# Altium schematic sheet SAIDSense.SchDoc
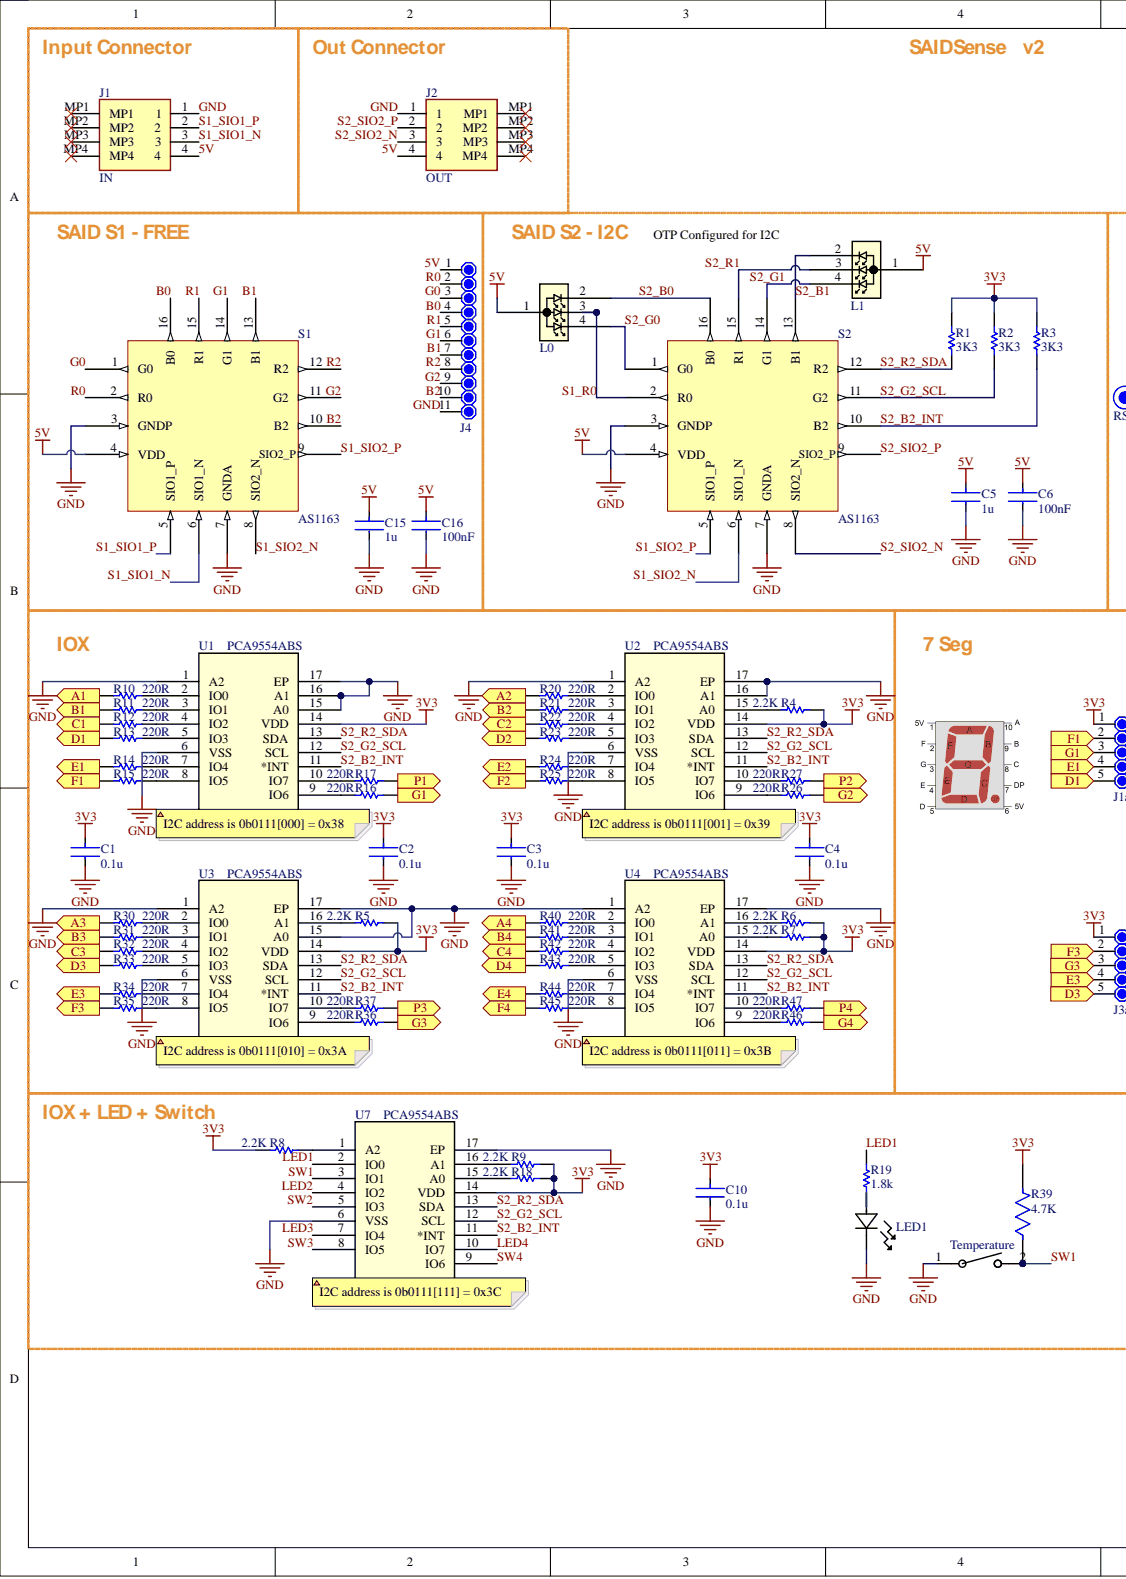
[diagram p0: SAIDSense.SchDoc, left]
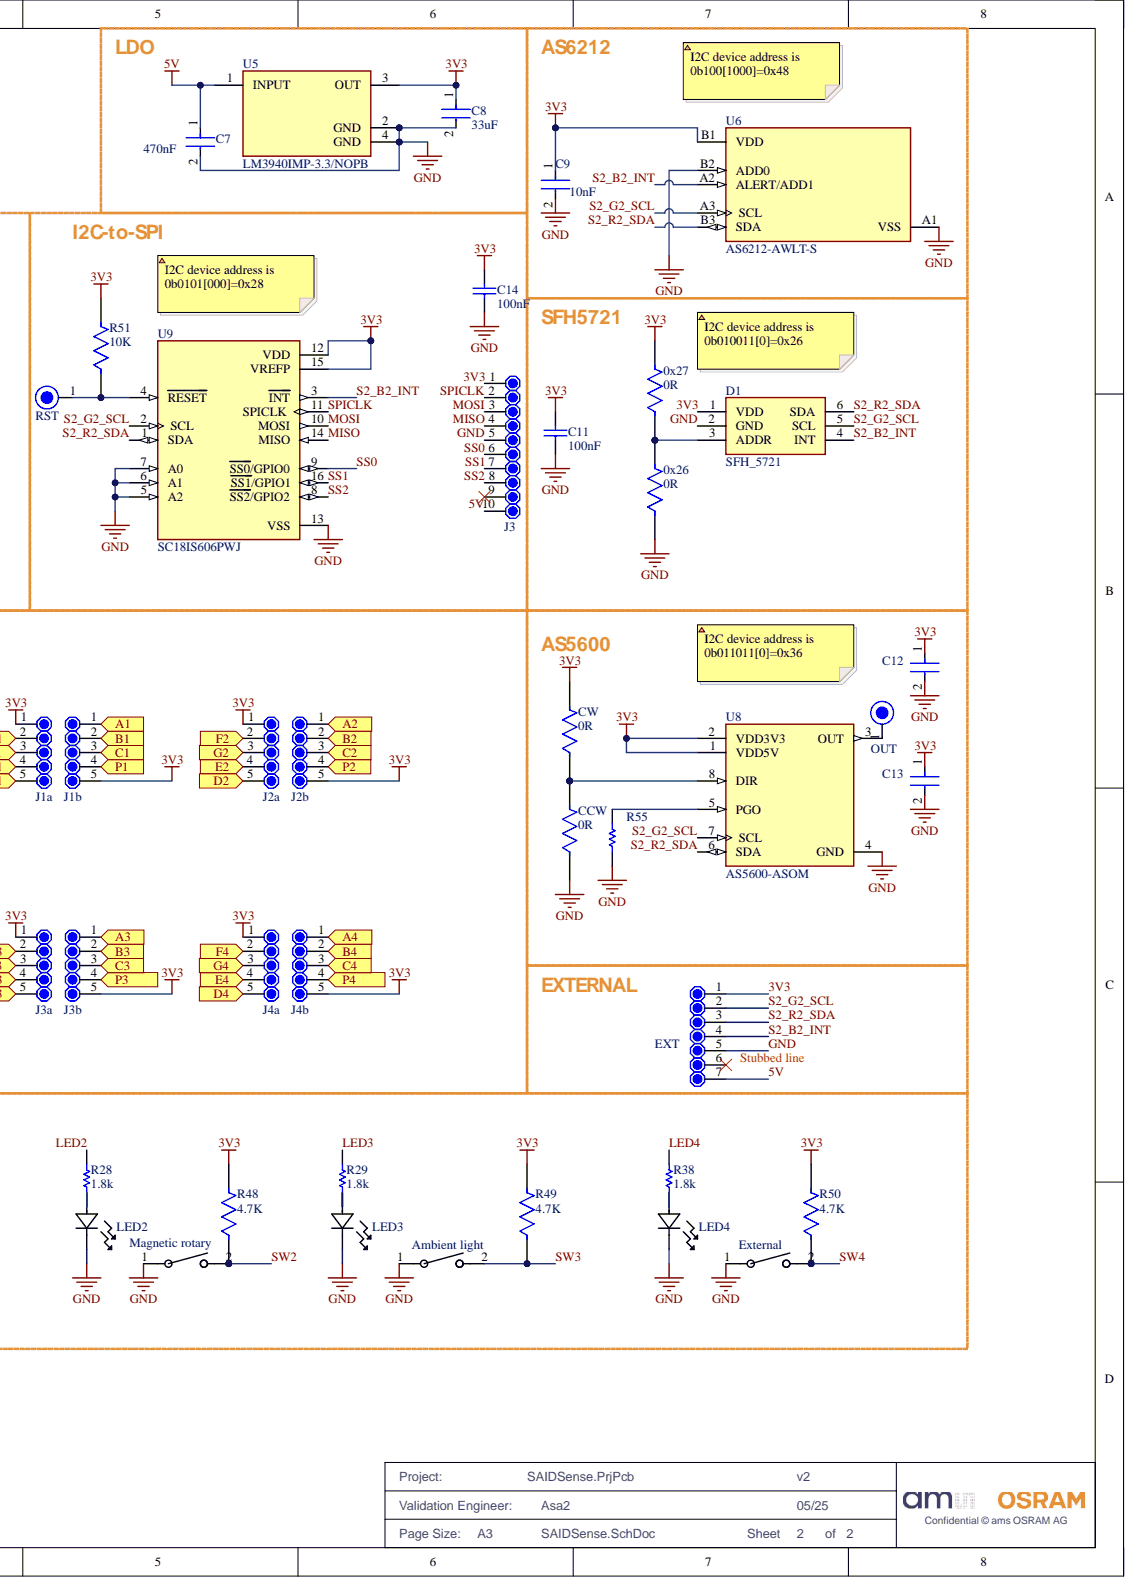
[diagram p1: SAIDSense.SchDoc, right]

## Parts
0x26  0R  [FP-CRG0603-IPC_C]
0x27  0R  [FP-CRG0603-IPC_C]  (no BOM)
Ambient_light  EVP-CD7C1A000  [SW_EVPCD7C1A000_PAN]  (value not drawn)
C1  0.1u  [FP-CGA3E-0_3-0_1-IPC_A]
C2  0.1u  [FP-CGA3E-0_3-0_1-IPC_A]
C3  0.1u  [FP-CGA3E-0_3-0_1-IPC_A]
C4  0.1u  [FP-CGA3E-0_3-0_1-IPC_A]
C5  1u  [FP-0603-L_1_6_0_15-W_0_81-MFG]
C6  100nF  [FP-CGA3E-0_3-0_1-IPC_A]
C7  470nF  [FP-CL10-IPC_A]
C8  33uF  [FP-C2012-125-0_2-IPC_A]
C9  10nF  [FP-C0603C-CF-MFG]
C10  0.1u  [FP-CGA3E-0_3-0_1-IPC_A]
C11  100nF  [CAPC1005X56X25LL10T15]
C12  CL05A106MP5NUNC  [FP-CL05A106MP5NUNC-MFG]  (value not drawn)
C13  CL05A106MP5NUNC  [FP-CL05A106MP5NUNC-MFG]  (value not drawn)
C14  100nF  [CAPC1005X56X25LL10T15]
C15  1u  [FP-0603-L_1_6_0_15-W_0_81-MFG]
C16  100nF  [FP-CGA3E-0_3-0_1-IPC_A]
CCW  0R  [FP-CRG0603-IPC_C]  (no BOM)
CW  0R  [FP-CRG0603-IPC_C]  (no BOM)
D1  SFH_5721  [SFH5721]
EXT  Pin Strip 7  [Pin_Strip_7]  (value not drawn)  (no BOM)
External  EVP-CD7C1A000  [SW_EVPCD7C1A000_PAN]  (value not drawn)
J1  IN  [214012E]
J1a  Pin Strip 5  [Pin_Strip_5]  (value not drawn)
J1b  Pin Strip 5  [Pin_Strip_5]  (value not drawn)
J2  OUT  [214012E]
J2a  Pin Strip 5  [Pin_Strip_5]  (value not drawn)
J2b  Pin Strip 5  [Pin_Strip_5]  (value not drawn)
J3  I2C-to-SPI  [Pin_Strip_10]
J3a  Pin Strip 5  [Pin_Strip_5]  (value not drawn)
J3b  Pin Strip 5  [Pin_Strip_5]  (value not drawn)
J4  Pin Strip 11  [Pin_Strip_11]  (value not drawn)
J4a  Pin Strip 5  [Pin_Strip_5]  (value not drawn)
J4b  Pin Strip 5  [Pin_Strip_5]  (value not drawn)
L0  (no value)  [KRTBDWLM32.32_AOS]
L1  (no value)  [KRTBDWLM32.32_AOS]
LED1  KW DELSS2.CC-BXCY-4O9Q-46A8  [LED_OSTUNE-E1608_AOS]  (value not drawn)
LED2  KW DELSS2.CC-BXCY-4O9Q-46A8  [LED_OSTUNE-E1608_AOS]  (value not drawn)
LED3  KW DELSS2.CC-BXCY-4O9Q-46A8  [LED_OSTUNE-E1608_AOS]  (value not drawn)
LED4  KW DELSS2.CC-BXCY-4O9Q-46A8  [LED_OSTUNE-E1608_AOS]  (value not drawn)
Magnetic_rotary  EVP-CD7C1A000  [SW_EVPCD7C1A000_PAN]  (value not drawn)
R1  3K3  [RESC0603(1608)_N]
R2  3K3  [RESC0603(1608)_N]
R3  3K3  [RESC0603(1608)_N]
R4  2.2K  [RESC1609X50X30NL10T20]
R5  2.2K  [RESC1609X50X30NL10T20]
R6  2.2K  [RESC1609X50X30NL10T20]
R7  2.2K  [RESC1609X50X30NL10T20]
R8  2.2K  [RESC1609X50X30NL10T20]
R9  2.2K  [RESC1609X50X30NL10T20]
R10  220R  [RESC1608X55X30NL20T10]
R11  220R  [RESC1608X55X30NL20T10]
R12  220R  [RESC1608X55X30NL20T10]
R13  220R  [RESC1608X55X30NL20T10]
R14  220R  [RESC1608X55X30NL20T10]
R15  220R  [RESC1608X55X30NL20T10]
R16  220R  [RESC1608X55X30NL20T10]
R17  220R  [RESC1608X55X30NL20T10]
R18  2.2K  [RESC1609X50X30NL10T20]
R19  1.8k  [RESC1608X55X30LL15T15]
R20  220R  [RESC1608X55X30NL20T10]
R21  220R  [RESC1608X55X30NL20T10]
R22  220R  [RESC1608X55X30NL20T10]
R23  220R  [RESC1608X55X30NL20T10]
R24  220R  [RESC1608X55X30NL20T10]
R25  220R  [RESC1608X55X30NL20T10]
R26  220R  [RESC1608X55X30NL20T10]
R27  220R  [RESC1608X55X30NL20T10]
R28  1.8k  [RESC1608X55X30LL15T15]
R29  1.8k  [RESC1608X55X30LL15T15]
R30  220R  [RESC1608X55X30NL20T10]
R31  220R  [RESC1608X55X30NL20T10]
R32  220R  [RESC1608X55X30NL20T10]
R33  220R  [RESC1608X55X30NL20T10]
R34  220R  [RESC1608X55X30NL20T10]
R35  220R  [RESC1608X55X30NL20T10]
R36  220R  [RESC1608X55X30NL20T10]
R37  220R  [RESC1608X55X30NL20T10]
R38  1.8k  [RESC1608X55X30LL15T15]
R39  4.7K  [FP-ERA3A-IPC_C]
R40  220R  [RESC1608X55X30NL20T10]
R41  220R  [RESC1608X55X30NL20T10]
R42  220R  [RESC1608X55X30NL20T10]
R43  220R  [RESC1608X55X30NL20T10]
R44  220R  [RESC1608X55X30NL20T10]
R45  220R  [RESC1608X55X30NL20T10]
R46  220R  [RESC1608X55X30NL20T10]
R47  220R  [RESC1608X55X30NL20T10]
R48  4.7K  [FP-ERA3A-IPC_C]
R49  4.7K  [FP-ERA3A-IPC_C]
R50  4.7K  [FP-ERA3A-IPC_C]
R51  10K  [FP-RC0402-0_4-IPC_C]
R55  CRCW06030000Z0EA  [RESC1609X50X30NL8T20]  (value not drawn)  (no BOM)
S1  AS1163  [QFN50P300X300X85_HS-17N]
S2  AS1163  [QFN50P300X300X85_HS-17N]
Temperature  EVP-CD7C1A000  [SW_EVPCD7C1A000_PAN]  (value not drawn)
TP1  OUT  [TESTPOINT]
TP2  RST  [TESTPOINT]
U1  PCA9554ABS  [SOT629-1_NXP]
U2  PCA9554ABS  [SOT629-1_NXP]
U3  PCA9554ABS  [SOT629-1_NXP]
U4  PCA9554ABS  [QFN50P300X300X100-17N-D]
U5  LM3940IMP-3.3/NOPB  [FP-DCY0004A-MFG]
U6  AS6212-AWLT-S  [FP-AS6212-AWLT-S-IPC_C]
U7  PCA9554ABS  [QFN50P300X300X100-17N-D]
U8  AS5600-ASOM  [FP-AS5600-ASOM-IPC_C]
U9  SC18IS606PWJ  [SOP65P640X110-16N]

## Ports
A1, A2, A3, A4, B1, B2, B3, B4, C1, C2, C3, C4, D1, D2, D3, D4, E1, E2, E3, E4, F1, F2, F3, F4, G1, G2, G3, G4, P1, P2, P3, P4

## Nets
3V3: 0x27.2 C1.1 C2.1 C3.1 C4.1 C8.1 C9.1 C10.1 C11.1 C12.1 C13.1 C14.1 CW.2 D1.1(VDD) EXT.1 J1a.1 J1b.5 J2a.1 J2b.5 J3.1 J3a.1 J3b.5 J4a.1 J4b.5 R1.2 R2.2 R3.2 R4.2 R5.2 R6.2 R7.2 R8.1 R9.2 R18.2 R39.2 R48.2 R49.2 R50.2 R51.2 U1.14(VDD) U2.14(VDD) U3.14(VDD) U4.14(VDD) U5.3(OUT) U6.B1(VDD) U7.14(VDD) U8.1(VDD5V) U8.2(VDD3V3) U9.12(VDD) U9.15(VREFP)
5V: C5.1 C6.1 C7.1 C15.1 C16.1 EXT.7 J1.4 J2.4 J3.10 J4.1 L0.1 L1.1 S1.4(VDD) S2.4(VDD) U5.1(INPUT)
A1: J1b.1 R10.1 ; port A1
A2: J2b.1 R20.1 ; port A2
A3: J3b.1 R30.1 ; port A3
A4: J4b.1 R40.1 ; port A4
B0: J4.4 S1.16(B0)
B1: J4.7 S1.13(B1)
B2: J4.10 S1.10(B2)
B3: J3b.2 R31.1 ; port B3
B4: J4b.2 R41.1 ; port B4
C1: J1b.3 R12.1 ; port C1
C2: J2b.3 R22.1 ; port C2
C3: J3b.3 R32.1 ; port C3
C4: J4b.3 R42.1 ; port C4
D1: J1a.5 R13.1 ; port D1
D2: J2a.5 R23.1 ; port D2
D3: J3a.5 R33.1 ; port D3
D4: J4a.5 R43.1 ; port D4
E1: J1a.4 R14.1 ; port E1
E2: J2a.4 R24.1 ; port E2
E3: J3a.4 R34.1 ; port E3
E4: J4a.4 R44.1 ; port E4
F1: J1a.2 R15.1 ; port F1
F2: J2a.2 R25.1 ; port F2
F3: J3a.2 R35.1 ; port F3
F4: J4a.2 R45.1 ; port F4
G0: J4.3 S1.1(G0)
G1: J4.6 S1.14(G1)
G2: J4.9 S1.11(G2)
G3: J3a.3 R36.2 ; port G3
G4: J4a.3 R46.2 ; port G4
GND: 0x26.1 Ambient_light.1 C1.2 C2.2 C3.2 C4.2 C5.2 C6.2 C7.2 C8.2 C9.2 C10.2 C11.2 C12.2 C13.2 C14.2 C15.2 C16.2 CCW.1 D1.2(GND) EXT.5 External.1 J1.1 J2.1 J3.5 J4.11 LED1.1 LED2.1 LED3.1 LED4.1 Magnetic_rotary.1 R55.1 S1.3(GNDP) S1.7(GNDA) S2.3(GNDP) S2.7(GNDA) Temperature.1 U1.1(A2) U1.6(VSS) U1.15(A0) U1.16(A1) U1.17(EP) U2.1(A2) U2.6(VSS) U2.16(A1) U2.17(EP) U3.1(A2) U3.6(VSS) U3.15(A0) U3.17(EP) U4.1(A2) U4.6(VSS) U4.17(EP) U5.2(GND) U5.4(GND) U6.A1(VSS) U6.B2(ADD0) U7.6(VSS) U7.17(EP) U8.4(GND) U9.5(A2) U9.6(A1) U9.7(A0) U9.13(VSS)
LED1: R19.1 U7.2(IO0)
LED2: R28.1 U7.4(IO2)
LED3: R29.2 U7.7(IO4)
LED4: R38.2 U7.10(IO7)
MISO: J3.4 U9.14(MISO)
MOSI: J3.3 U9.10(MOSI)
P1: J1b.4 R17.2 ; port P1
P2: J2b.4 R27.2 ; port P2
P3: J3b.4 R37.2 ; port P3
P4: J4b.4 R47.2 ; port P4
R0: J4.2 S1.2(R0)
R1: J4.5 S1.15(R1)
R2: J4.8 S1.12(R2)
S1_R0: L0.3 S2.2(R0)
S1_SIO1_N: J1.3 S1.6(SIO1_N)
S1_SIO1_P: J1.2 S1.5(SIO1_P)
S1_SIO2_N: S1.8(SIO2_N) S2.6(SIO1_N)
S1_SIO2_P: S1.9(SIO2_P) S2.5(SIO1_P)
S2_B0: L0.2 S2.16(B0)
S2_B1: L1.2 S2.13(B1)
S2_B2_INT: D1.4(INT) EXT.4 R3.1 S2.10(B2) U1.11(*INT) U2.11(*INT) U3.11(*INT) U4.11(*INT) U6.A2(ALERT/ADD1) U7.11(*INT) U9.3(INT)
S2_G0: L0.4 S2.1(G0)
S2_G1: L1.4 S2.14(G1)
S2_G2_SCL: D1.5(SCL) EXT.2 R2.1 S2.11(G2) U1.12(SCL) U2.12(SCL) U3.12(SCL) U4.12(SCL) U6.A3(SCL) U7.12(SCL) U8.7(SCL) U9.2(SCL)
S2_R1: L1.3 S2.15(R1)
S2_R2_SDA: D1.6(SDA) EXT.3 R1.1 S2.12(R2) U1.13(SDA) U2.13(SDA) U3.13(SDA) U4.13(SDA) U6.B3(SDA) U7.13(SDA) U8.6(SDA) U9.1(SDA)
S2_SIO2_N: J2.3 S2.8(SIO2_N)
S2_SIO2_P: J2.2 S2.9(SIO2_P)
SPICLK: J3.2 U9.11(SPICLK)
SS0: J3.6 U9.9(SS0/GPIO0)
SS1: J3.7 U9.16(SS1/GPIO1)
SS2: J3.8 U9.8(SS2/GPIO2)
SW1: R39.1 Temperature.2 U7.3(IO1)
SW2: Magnetic_rotary.2 R48.1 U7.5(IO3)
SW3: Ambient_light.2 R49.1 U7.8(IO5)
SW4: External.2 R50.1 U7.9(IO6)
Net0x26_2: 0x26.2 0x27.1 D1.3(ADDR)
NetCCW_2: CCW.2 CW.1 U8.8(DIR)
NetJ1a_3: J1a.3 R16.2 ; port G1
NetJ1b_2: J1b.2 R11.1 ; port B1
NetJ2a_3: J2a.3 R26.2 ; port G2
NetJ2b_2: J2b.2 R21.1 ; port B2
NetLED1_2: LED1.2 R19.2
NetLED2_2: LED2.2 R28.2
NetLED3_2: LED3.2 R29.1
NetLED4_2: LED4.2 R38.1
NetR4_1: R4.1 U2.15(A0)
NetR5_1: R5.1 U3.16(A1)
NetR6_1: R6.1 U4.16(A1)
NetR7_1: R7.1 U4.15(A0)
NetR8_2: R8.2 U7.1(A2)
NetR9_1: R9.1 U7.16(A1)
NetR10_2: R10.2 U1.2(IO0)
NetR11_2: R11.2 U1.3(IO1)
NetR12_2: R12.2 U1.4(IO2)
NetR13_2: R13.2 U1.5(IO3)
NetR14_2: R14.2 U1.7(IO4)
NetR15_2: R15.2 U1.8(IO5)
NetR16_1: R16.1 U1.9(IO6)
NetR17_1: R17.1 U1.10(IO7)
NetR18_1: R18.1 U7.15(A0)
NetR20_2: R20.2 U2.2(IO0)
NetR21_2: R21.2 U2.3(IO1)
NetR22_2: R22.2 U2.4(IO2)
NetR23_2: R23.2 U2.5(IO3)
NetR24_2: R24.2 U2.7(IO4)
NetR25_2: R25.2 U2.8(IO5)
NetR26_1: R26.1 U2.9(IO6)
NetR27_1: R27.1 U2.10(IO7)
NetR30_2: R30.2 U3.2(IO0)
NetR31_2: R31.2 U3.3(IO1)
NetR32_2: R32.2 U3.4(IO2)
NetR33_2: R33.2 U3.5(IO3)
NetR34_2: R34.2 U3.7(IO4)
NetR35_2: R35.2 U3.8(IO5)
NetR36_1: R36.1 U3.9(IO6)
NetR37_1: R37.1 U3.10(IO7)
NetR40_2: R40.2 U4.2(IO0)
NetR41_2: R41.2 U4.3(IO1)
NetR42_2: R42.2 U4.4(IO2)
NetR43_2: R43.2 U4.5(IO3)
NetR44_2: R44.2 U4.7(IO4)
NetR45_2: R45.2 U4.8(IO5)
NetR46_1: R46.1 U4.9(IO6)
NetR47_1: R47.1 U4.10(IO7)
NetR51_1: R51.1 TP2.1 U9.4(RESET)
NetR55_2: R55.2 U8.5(PGO)
NetTP1_1: TP1.1 U8.3(OUT)

Not connected: EXT 6; J1 MP1 MP2 MP3 MP4; J2 MP1 MP2 MP3 MP4; J3 9

## Library data of the parts
0x26 0x27 CCW CW = CMP-03211-011415-1: RES SMD 0 OHM JUMPER 1/10W 0603; Max Power Dissipation: 100mW; Number of Resistors: 1; Resistance: 0mR; Temperature Coefficient: 100ppm/°C; Tolerance: 0%; Voltage Rating: 50V; Case Code (Imperial): 0603; Case Code (Metric): 1608; Case/Package: 0603; Depth: 0.8mm; ELV: Compliant; Height: 0.45mm; Length: 1.6mm; Library Name: Altium Content Vault; Max Operating Temperature: 125°C; Min Operating Temperature: -55°C; Mount: Surface Mount; Number of Terminations: 2; Package Quantity: 5000; Packaging: Tape and Reel; Power Rating: 100mW; Radiation Hardening: No; Resistor Type: Thick Film; RoHS Compliant: Yes; Series: CRG, Neohm; Technology: THICK FILM; Termination: Solder; Weight: 7.1E-05oz; Width: 0.8mm
  0x26: Component Kind: Standard
Ambient_light External Magnetic_rotary Temperature = EVP-CD7C1A000: No Description Available; Mfr_Name: Panasonic; Component Kind: Standard; Library Name: EVP-CD7C1A000.SchLib
C1-C4 C6 C10 C16 = CMP-2000-07546-2: Cap Ceramic 100nF 50V X7R 10% Pad SMD 0603 +125°C Automotive T/R; Capacitance: 100nF; Case Code (Imperial): 0603; Case Code (Metric): 1608; Case/Package: 0603; Component Kind: Standard; Composition: Ceramic; Depth: 0.8mm; Dielectric: X7R; Height: 0.8mm; Lead Free: Lead Free; Length: 1.6mm; Library Name: Altium Content Vault; Max Operating Temperature: 125°C; Min Operating Temperature: -55°C; Mount: Surface Mount; Package Quantity: 1; Packaging: Tape and Reel; Radiation Hardening: No; Ratings: AEC-Q200; REACH SVHC: No SVHC; Termination: SMD/SMT; Thickness: 0.035inch; Tolerance: 10%; Voltage: 50V; Voltage Rating: 50V; Voltage Rating (DC): 50V; Weight: 7.1E-05oz; Width: 0.8mm
C5 C15 = CMP-1035-02592-4: General Purpose Ceramic Capacitor, 0603, 1u, 10%, X7R, 15%, 50V; Capacitance: 100nF; Dissipation Factor: 2.5%; Case Code (Imperial): 0603; Case Code (Metric): 1608; Case/Package: 0603; Component Kind: Standard; Contact Plating: Tin; Depth: 0.81mm; Dielectric: X7R; Dielectric Material: Ceramic; Height: 0.9mm; Lead Free: Lead Free; Length: 1.6mm; Library Name: Altium Content Vault; Max Operating Temperature: 125°C; Min Operating Temperature: -55°C; Mount: Surface Mount; Number of Pins: 2; Package Quantity: 1; Packaging: Tape and Reel; Radiation Hardening: No; REACH SVHC: No SVHC; Termination: SMD/SMT; Thickness: 0.035inch; Tolerance: 10%; Voltage: 50V; Voltage Rating: 50V; Voltage Rating (DC): 50V; Width: 0.81mm
C7 = CMP-13271-003124-1: Cap Ceramic 470nF 25V X7R ±10% Pad SMD 0603 +125°C T/R; Capacitance: 470nF; Case Code (Imperial): 0603; Case Code (Metric): 1608; Case/Package: 0603; Component Kind: Standard; Contact Plating: Tin; Dielectric: X7R; Length: 0.063inch; Library Name: Altium Content Vault; Max Operating Temperature: 125°C; Min Operating Temperature: -55°C; Mount: Surface Mount; Package Quantity: 1; Packaging: Cut Tape; Termination: Wraparound; Thickness: 0.035inch; Tolerance: 10%; Voltage Rating: 25V; Width: 0.031inch
C8 = CMP-08246-005951-1: Multilayer Ceramic Capacitors 33μF ±20% 4V X6S SMD 0805; Capacitance: 33uF; Case Code (Imperial): 0805; Case Code (Metric): 2012; Case/Package: 0805; Component Kind: Standard; Dielectric: X6S; Dielectric Material: Ceramic; Height: 1.25mm; Lead Free: Lead Free; Length: 0.079inch; Library Name: Altium Content Vault; Max Operating Temperature: 105°C; Min Operating Temperature: -55°C; Mount: Surface Mount; Package Quantity: 2000; Packaging: Tape and Reel; Resistor Type: Ceramic; RoHS Compliant: Yes; Series: C; Termination: SMD/SMT; Thickness: 0.057inch; Tolerance: 20%; Voltage Rating: 4V; Voltage Rating (DC): 4V; Width: 0.049inch
C9 = CMP-1035-02317-2: C0603 10,000 pF X7R 30ppm/°C 10.00% 25 V; Manufacturer: KEMET; Manufacturer Part Number: C0603C103K3RAC; Automotive Grade: Grade 1; Material: Ceramic; Tolerance: 0.1; Voltage Rating: 25 V; Automotive: Yes; Capacitance: 10,000 pF; Category: Cap; Component Kind: Standard; ComponentLink2Description: Datasheet; ComponentLink2URL: https://api.kemet.com/component-edge/download/datasheet/C0603C100J5GACAUTO.pdf; Device Class L1: Passive Components; Device Class L2: Capacitors; Device Class L3: Ceramic Capacitors; Height: 0.87mm; Lead Free: Yes; Library Name: Altium Content Vault; Mouser Description: Cap Ceramic 0.01uF 25V X7R 10% Pad SMD 0603 125C Bulk; Octopart: https://octopart.com/c0603c103k3rac-kemet-2224274; Package: C0603C-CF; RoHS: TRUE; Temperature: -55°C to +125°C; Temperature Characteristic: X7R; Temperature Coefficient: 30ppm/°C; Temperature Range High: +125°C; Temperature Range Low: -55°C; Value: 10,000 pF
C11 C14 = CMP-2008-02899-1: Manufacturer: AVX Interconnect / Elco; Part Number: 0402ZD104JAT2A; Component Kind: Standard; Library Name: Altium Content Vault
C12 C13 = CMP-13271-000002-1: CAP CER 10UF 10V X5R 0402; Manufacturer: Samsung; Material: Ceramic; Temperature Coefficient: 15%; Tolerance: 20%; Automotive: No; Capacitance: 10uF; Category: Cap; Component Kind: Standard; ComponentLink2Description: Datasheet; ComponentLink2URL: http://www.samsungsem.com/kr/support/product-search/mlcc/__icsFiles/afieldfile/2019/05/10/19-CL05A106MP5NUNC.pdf; Device Class L1: Passive Components; Device Class L2: Capacitors; Device Class L3: Ceramic Capacitors; Digikey Description: CAP CER 10UF 10V X5R 0402; Height: 0.7mm; Ipc Land Pattern Name: CAPC10050X50; Lead Free: Yes; Library Name: Altium Content Vault; Manufacturer Part Number: CL05A106MP5NUNC; Octopart: https://octopart.com/cl05a106mp5nunc-samsung-22457395; Package: CL05A106MP5NUNC; RoHS: TRUE; Temperature: -55°C to +85°C; Temperature Characteristic: X5R; Temperature Range High: +85°C; Temperature Range Low: -55°C; Value: 10uF; Voltage Rating: 10V
D1 = SFH_5721: Ambient Light Sensors ALS / PS Emitter ChipLED; Manufacturer_Name: ams OSRAM; Geometry.Height: 0.6mm; Component Kind: Standard; Datasheet Link: https://look.ams-osram.com/m/149e2b6ba561084/original/SFH-5721.pdf; Library Name: SFH_5721.SchLib; Manufacturer_Part_Number: SFH 5721; Mouser Part Number: 720-SFH5721A9489; Mouser Price/Stock: https://www.mouser.co.uk/ProductDetail/ams-OSRAM/SFH-5721?qs=TuK3vfAjtkVuP6sTM9hwPg%3D%3D
EXT = Pin_Strip_7: Manufacturer: Fischer Elektronik; Manufacturer Part Number: SL1.025.36Z; Current Rating: 3A; Gender: Male; Max Voltage Rating (AC): 250V; Number of Contacts: 36; Pitch: 2.54mm; Colour: Black; ComponentLink1Description: http://www.farnell.com/datasheets/12129.pdf; ComponentLink1URL: http://www.farnell.com/datasheets/12129.pdf; ComponentLink2Description: https://www.verical.com/server-webapp/html/getDatasheet?partID=640159; ComponentLink2URL: https://www.verical.com/server-webapp/html/getDatasheet?partID=640159; Contact Material: Bronze,Copper,Copper Alloy,Tin; Contact Plating: Tin; Depth: 2.5mm; Description: Pin Strip 7; Flammability Rating: UL94 V-0; Height: 2.5mm; Housing Material: Polyamide; Length: 91.44mm; Library Name: Mechanical_3D.SchLib; Max Current Rating: 3A; Max Operating Temperature: 163°C; Min Operating Temperature: -40°C; Number of Rows: 1; Orientation: Straight; REACH SVHC: No SVHC; Stock 1: 0; Supplier 1: Farnell; Supplier Part Number 1: 9729038; Termination: Solder; Width: 11.2mm
J1 J2 = 214012-E: Headers & Wire Housings 4-PIN,RIGHTANGLE,SMT; Manufacturer_Name: TE Connectivity; Geometry.Height: 2.98mm; Arrow Part Number: 214012-E; Arrow Price/Stock: https://www.arrow.com/en/products/214012-e/te-connectivity?region=europe; Component Kind: Standard; Datasheet Link: https://www.te.com/commerce/DocumentDelivery/DDEController?Action=showdoc&DocId=Customer+Drawing%7F214012-E%7FK%7Fpdf%7FEnglish%7FENG_CD_214012-E_K.pdf%7F214012-E; Library Name: 214012-E.SchLib; Manufacturer_Part_Number: 214012-E
J1a J1b J2a J2b J3a J3b J4a J4b = Pin_Strip_5: Manufacturer: Fischer Elektronik; Manufacturer Part Number: SL1.025.36Z; Current Rating: 3A; Gender: Male; Max Voltage Rating (AC): 250V; Number of Contacts: 36; Pitch: 2.54mm; Colour: Black; Component Kind: Standard; ComponentLink1Description: http://www.farnell.com/datasheets/12129.pdf; ComponentLink1URL: http://www.farnell.com/datasheets/12129.pdf; ComponentLink2Description: https://www.verical.com/server-webapp/html/getDatasheet?partID=640159; ComponentLink2URL: https://www.verical.com/server-webapp/html/getDatasheet?partID=640159; Contact Material: Bronze,Copper,Copper Alloy,Tin; Contact Plating: Tin; Depth: 2.5mm; Description: Pin Strip 5; Flammability Rating: UL94 V-0; Height: 2.5mm; Housing Material: Polyamide; Length: 91.44mm; Library Name: Mechanical_3D.SchLib; Max Current Rating: 3A; Max Operating Temperature: 163°C; Min Operating Temperature: -40°C; Number of Rows: 1; Orientation: Straight; REACH SVHC: No SVHC; Stock 1: 0; Supplier 1: Farnell; Supplier Part Number 1: 9729038; Termination: Solder; Width: 11.2mm
J3 = Pin_Strip_10: Pin Strip 10; Manufacturer: Fischer Elektronik; Manufacturer Part Number: SL1.025.36Z; Current Rating: 3A; Gender: Male; Max Voltage Rating (AC): 250V; Number of Contacts: 36; Pitch: 2.54mm; Colour: Black; Component Kind: Standard; ComponentLink1Description: http://www.farnell.com/datasheets/12129.pdf; ComponentLink1URL: http://www.farnell.com/datasheets/12129.pdf; ComponentLink2Description: https://www.verical.com/server-webapp/html/getDatasheet?partID=640159; ComponentLink2URL: https://www.verical.com/server-webapp/html/getDatasheet?partID=640159; Contact Material: Bronze,Copper,Copper Alloy,Tin; Contact Plating: Tin; Depth: 2.5mm; Flammability Rating: UL94 V-0; Height: 2.5mm; Housing Material: Polyamide; Length: 91.44mm; Library Name: Mechanical_3D.SchLib; Max Current Rating: 3A; Max Operating Temperature: 163°C; Min Operating Temperature: -40°C; Number of Rows: 1; Orientation: Straight; REACH SVHC: No SVHC; Stock 1: 0; Supplier 1: Farnell; Supplier Part Number 1: 9729038; Termination: Solder; Width: 11.2mm
J4 = Pin_Strip_11: Manufacturer: Fischer Elektronik; Manufacturer Part Number: SL1.025.36Z; Current Rating: 3A; Gender: Male; Max Voltage Rating (AC): 250V; Number of Contacts: 36; Pitch: 2.54mm; Colour: Black; Component Kind: Standard; ComponentLink1Description: http://www.farnell.com/datasheets/12129.pdf; ComponentLink1URL: http://www.farnell.com/datasheets/12129.pdf; ComponentLink2Description: https://www.verical.com/server-webapp/html/getDatasheet?partID=640159; ComponentLink2URL: https://www.verical.com/server-webapp/html/getDatasheet?partID=640159; Contact Material: Bronze,Copper,Copper Alloy,Tin; Contact Plating: Tin; Depth: 2.5mm; Description: Pin Strip 11; Flammability Rating: UL94 V-0; Height: 2.5mm; Housing Material: Polyamide; Length: 91.44mm; Library Name: Mechanical_3D.SchLib; Max Current Rating: 3A; Max Operating Temperature: 163°C; Min Operating Temperature: -40°C; Number of Rows: 1; Orientation: Straight; REACH SVHC: No SVHC; Stock 1: 0; Supplier 1: Farnell; Supplier Part Number 1: 9729038; Termination: Solder; Width: 11.2mm
L0 L1 = KRTBDWLM32.32-T4U6-JW+AAAB-J3R4S6-5V-S: No Description Available; Mfr_Name: ams OSRAM; Type: LED Indication; Component Kind: Standard; Library Name: KRTBDWLM32P32-T4U6-JW&plus_AAAB-J3R4S6-5V-S.SchLib; Manufacturer_Part_Number: KRTBDWLM32.32-T4U6-JW+AAAB-J3R4S6-5V-S; RefDes: RefDes; Copyright: Copyright (C) 2023 Ultra Librarian. All rights reserved.
LED1-LED4 = KW DELSS2.CC-BXCY-4O9Q-46A8: No Description Available; Mfr_Name: ams OSRAM; Type: DEV; Component Kind: Standard; Library Name: KWDELSS2PCC-BXCY-4O9Q-46A8.SchLib; Manufacturer_Part_Number: KW DELSS2.CC-BXCY-4O9Q-46A8; RefDes: RefDes; Copyright: Copyright (C) 2023 Ultra Librarian. All rights reserved.
R1-R3 = CMP-1012-00086-1: 3K3 0.1W 5% 0603 (1608 Metric)  SMD; Case-EIA: 0603; Case-Metric: 1608; Value: 3300.00 Ohm; Component Kind: Standard; Library Name: Altium Content Vault; Power: 0.1 W; Technology: SMT; Tolerance: 5 %
R4-R9 R18 = CMP-2000-03168-1: Manufacturer: Vishay Dale; Part Number: CRCW06032K20FKEB; Component Kind: Standard; Library Name: Altium Content Vault
R10-R17 R20-R27 R30-R37 R40-R47 = CMP-2000-01304-1: Manufacturer: KOA Speer; Part Number: RK73H1JTTD2200F; Component Kind: Standard; Library Name: Altium Content Vault
R19 R28 R29 R38 = CMP-2000-00336-1: Manufacturer: Panasonic; Part Number: ERJ3EKF2201V; Component Kind: Standard; Library Name: Altium Content Vault
R39 R48-R50 = CMP-2000-00874-2: RES SMD 4.7K OHM 0.1% 1/10W 0603; Max Power Dissipation: 100mW; Resistance: 10kR; Temperature Coefficient: 25ppm/°C; Voltage Rating: 75V; Case Code (Imperial): 0603; Case Code (Metric): 1608; Case/Package: 0603; Component Kind: Standard; Construction: Rectangular; Contact Plating: Tin; Depth: 0.8mm; Height: 0.45mm; Lead Free: Lead Free; Length: 1.6mm; Library Name: Altium Content Vault; Max Operating Temperature: 155°C; Military Standard: Not; Min Operating Temperature: -55°C; Mount: Surface Mount; Number of Pins: 2; Number of Terminations: 2; Package Quantity: 5000; Packaging: Tape and Reel; Power Rating: 100mW; Radiation Hardening: No; REACH SVHC: No SVHC; Resistance Tolerance: 0.1%; Resistor Type: Thin Film; RoHS Compliant: Yes; Series: ERA-3A; Size Code: 0603; Technology: METAL FILM; Termination: SMD/SMT; Tolerance: 0.1%; Voltage Rating (DC): 75V; Width: 0.8mm
R51 = CMP-2002-07915-3: RES 10K OHM 5% 1/16W 0402; Max Power Dissipation: 63mW; Power Rating: 62.5mW; Resistance: 10kR; Temperature Coefficient: 100ppm/°C; Voltage Rating: 50V; Case Code (Imperial): 0402; Case Code (Metric): 1005; Case/Package: 0402; Component Kind: Standard; Construction: Rectangular; Depth: 0.5mm; Features: Moisture Resistant; Height: 0.016inch; Lead Free: Lead Free; Length: 0.039inch; Library Name: Altium Content Vault; Max Operating Temperature: 155°C; Military Standard: Not; Min Operating Temperature: -55°C; Number of Terminations: 2; Package Quantity: 1; Packaging: Tape and Reel; Resistance Tolerance: 5%; Resistor Type: Thick Film; RoHS Compliant: Yes; Series: RC; Size Code: 0402; Termination: SMD/SMT; Tolerance: 5%; Width: 0.02inch
R55 = CMP-2000-03816-5: Chip Resistor, 0 Ohm, Jumper, 100 mW, -55 to 155 degC, 0603 (1608 Metric), RoHS, Tape and Reel; Manufacturer: Vishay Semiconductor; Voltage Rating: 75 V; ComponentLink1Description: Manufacturer URL; ComponentLink1URL: http://www.vishay.com/; ComponentLink2Description: Datasheet; ComponentLink2URL: http://www.vishay.com/docs/20035/dcrcwe3.pdf; DatasheetVersion: 06/2012; Height: 0.45 mm; Length: 1.55 mm; Library Name: Altium Content Vault; Max Operating Temperature: 155 degC; Min Operating Temperature: -55 degC; Mount: Surface Mount; Mounting Technology: Surface Mount; Package Quantity: 5000; PackageDescription: 2-Pin Surface Mount Device, Body 1.575 x 0.85 mm; PackageReference: 0603; Packaging: Tape and Reel; Pins: 2; REACH SVHC: Unknown; RoHSCompliant: true
S1 S2 = SAID: Component Kind: Standard; Library Name: SAID.SchLib
TP1 TP2 = Testpoint_SMD: Component Kind: Standard; Library Name: Mechanical_3D.SchLib
U1-U4 U7 = PCA9554ABS: No Description Available; Mfr_Name: NXP; Component Kind: Standard; Library Name: PCA9554ABS.SchLib; RefDes: RefDes; Type: Type
U5 = CMP-0062-01788-4: IC REG LINEAR 3.3V 1A SOT223-4; Dropout Voltage: 500mV; Max Input Voltage: 7.5V; Min Input Voltage: 4.5V; Number of Outputs: 1; Output Configuration: Positive; Output Type: Fixed; Quiescent Current: 15mA; Case/Package: SOT-223; Component Kind: Standard; Contact Plating: Tin; Current Rating: 1A; Height: 1.6mm; Lead Free: Lead Free; Length: 6.5mm; Library Name: Altium Content Vault; Max Operating Temperature: 125°C; Max Output Current: 1A; Max Output Voltage: 3.3V; Max Supply Voltage: 7.5V; Min Operating Temperature: -40°C; Min Supply Voltage: 4.5V; Mount: Surface Mount; Nominal Output Voltage: 3.3V; Number of Pins: 4; Number of Regulators: 1; Output Current: 1A; Output Voltage: 3.3V; Package Quantity: 1000; Packaging: Tape and Reel; Polarity: Positive; Radiation Hardening: No; REACH SVHC: No SVHC; Series: -; Termination: SMD/SMT; Width: 3.56mm
U6 = CMP-22837-000002-1: TEMP SENSOR WLCSP 6; Component Kind: Standard; Library Name: Altium Content Vault
U8 = CMP-22826-000002-1: SENSOR ANGLE 360DEG SMD; Sensing Method: Hall Effect; Component Kind: Standard; Lead Free: Lead Free; Library Name: Altium Content Vault; Max Operating Temperature: 125°C; Min Operating Temperature: -40°C; Mount: Surface Mount; Termination: SMD/SMT
U9 = SC18IS606PWJ: I2C to SPI Bridge I2C/SPI Interface 2.5V/3.3V 16-Pin TSSOP T/R; MF: NXP Semiconductors; Availability: In Stock; Check_prices: https://www.snapeda.com/parts/SC18IS606PWJ/NXP+Semiconductors/view-part/?ref=eda; Component Kind: Standard; Library Name: SC18IS606PWJ.SchLib; MP: SC18IS606PWJ; Package: None; Price: None; Purchase-URL: https://pricing.snapeda.com/search/part/SC18IS606PWJ/?ref=eda; SnapEDA_Link: https://www.snapeda.com/parts/SC18IS606PWJ/NXP+Semiconductors/view-part/?ref=snap
